# Altium schematic sheet motor_driver.SchDoc
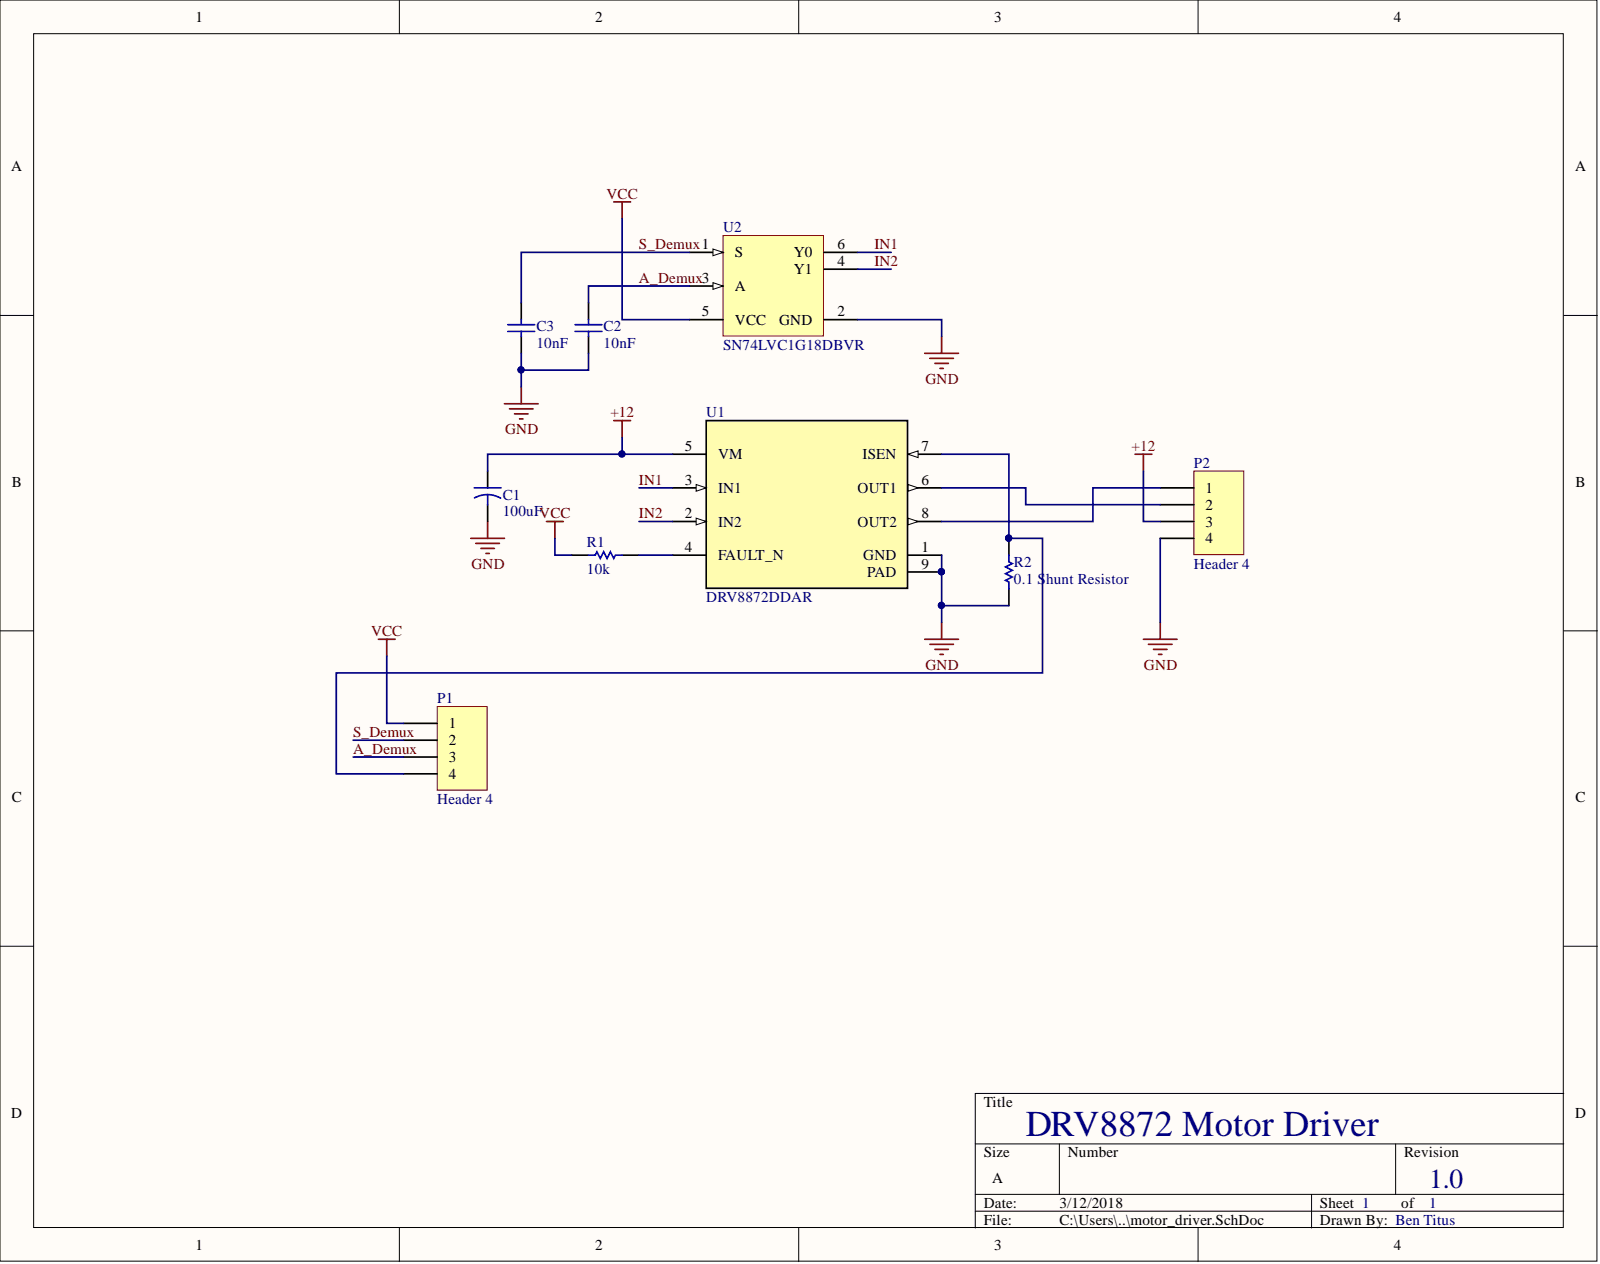
[diagram p0: motor_driver.SchDoc]

## Parts
C1  100uF  [CAPR5-4X5]
C2  10nF  [CAPC0805(2012)145_L]
C3  10nF  [CAPC0805(2012)145_L]
P1  Header 4  [HDR1X4]
P2  Header 4  [HDR1X4]
R1  10k  [RESC0805(2012)_L]
R2  0.1 Shunt Resistor  [RESC2512(6432)_L]
U1  DRV8872DDAR  [DDA0008H_N]
U2  SN74LVC1G18DBVR  [DBV0006A_L]

## Nets
+12: C1.1 P2.3 U1.5(VM)
GND: C1.2 C2.1 C3.1 P2.4 R2.1 U1.1(GND) U1.9(PAD) U2.2(GND)
VCC: P1.1 R1.1 U2.5(VCC)
A_Demux: C2.2 P1.3 U2.3(A)
IN1: U1.3(IN1) U2.6(Y0)
IN2: U1.2(IN2) U2.4(Y1)
S_Demux: C3.2 P1.2 U2.1(S)
NetP1_4: P1.4 R2.2 U1.7(ISEN)
NetP2_1: P2.1 U1.8(OUT2)
NetP2_2: P2.2 U1.6(OUT1)
NetR1_2: R1.2 U1.4(FAULT_N)

## Library data of the parts
C1 = Cap2: Capacitor; Component Kind: Standard; Library Name: Miscellaneous Devices.IntLib; PackageDescription: Capacitor; 2 Leads; PackageReference: CAPR5-4X5; Published: 23-Sep-2002; Signal Integrity: Cap; Simulation: CAP; Value: 100uF; Publisher: Altium Limited
C2 C3 = CMP-1590-00003-1: 0805 (2012 Metric) Chip Capacitor; Case-EIA: 0805; Case-Metric: 2012; Component Kind: Standard; Library Name: Altium Content Vault; PackageReference: CAPC0805(2012)145
P1 P2 = Header 4: Header, 4-Pin; Component Kind: Standard; LatestRevisionDate: 17-Jul-2002; LatestRevisionNote: Re-released for DXP Platform.; Library Name: Miscellaneous Connectors.IntLib; Signal Integrity: Connector; Publisher: Altium Limited
R1 = CMP-1591-00002-1: 0805 (2012 Metric) Chip Resistor; Case-EIA: 0805; Case-Metric: 2012; Component Kind: Standard; Library Name: Altium Content Vault; PackageReference: RESC0805(2012); Value: 10k
R2 = CMP-1591-00007-1: 2512 (6432 Metric) Chip Resistor; Case-EIA: 2512; Case-Metric: 6432; Component Kind: Standard; Library Name: Altium Content Vault; PackageReference: RESC2512(6432)
U1 = DRV8872DDAR: Imported; Manufacturer: Texas Instruments; CompType: IC; PIN_COUNT: 9; Alternate_PartNumber: DRV8872DDA; Component Kind: Standard; Library Name: Custom_Lib.IntLib; MountType: SMT; PackageReference: DDA0008H; PartNumber: DRV8872DDAR; RefDes: RefDes; TYPE: TYPE; Value: Value
U2 = CMP-0859-00184-3: One of Two Noninverting Demultiplexer with 3-State Deselected Output, DBV0006A, LARGE T&R; Manufacturer: Texas Instruments; 3-State Output: No; Bits(#): 1; F @ Nom Voltage(Max)(Mhz): 150; ICC @ Nom Voltage(Max)(mA): 0.01; Input Type: CMOS/TTL; Logic: True; Output Drive (IOL/IOH)(Max)(mA): 32/-32; Output Type: CMOS; Rating: Catalog; Specialty Logic Family: Decoder/Encoder/Multiplexer; Technology Family: LVC; tpd @ Nom Voltage(Max)(ns): 9.3,5,4.2,3.2; VCC(Max)(V): 5.5; VCC(Min)(V): 1.65; Voltage(Nom)(V): 1.8,2.5,3.3,5; Component Kind: Standard; DatasheetVersion: SCES406K; Generic Part Number: SN74LVC1G18; Library Name: Altium Content Vault; Operating Temperature Range(C): -40 to 85; PackageReference: DBV0006A; Schmitt Trigger: No; Sub-Family: Decoder/Encoder/Multiplexer
